# Altium schematic sheet WIFI Module.SchDoc("WIFI Module")
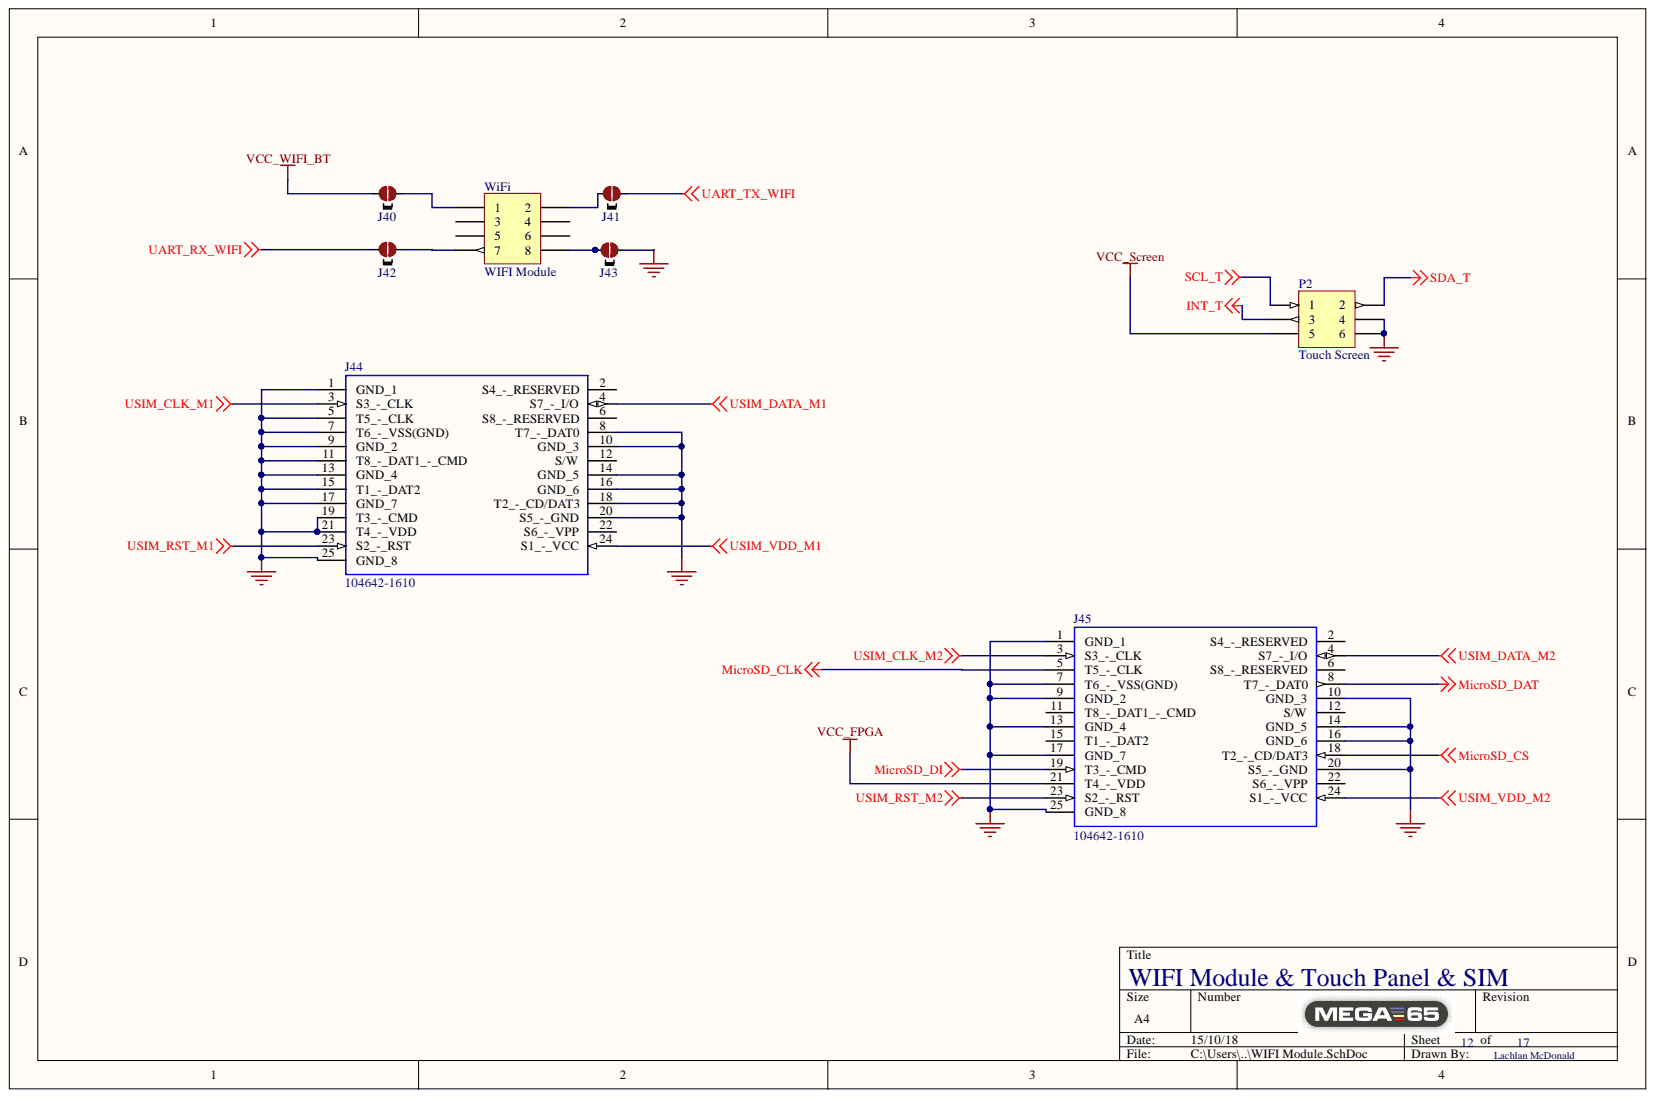
[diagram p0: WIFI Module.SchDoc]

## Parts
J40  2 way net solder junction - Normally Connected  [2waySolder_NC]  (value not drawn)  (no BOM)
J41  2 way net solder junction - Normally Connected  [2waySolder_NC]  (value not drawn)  (no BOM)
J42  2 way net solder junction - Normally Connected  [2waySolder_NC]  (value not drawn)  (no BOM)
J43  2 way net solder junction - Normally Connected  [2waySolder_NC]  (value not drawn)  (no BOM)
J44  104642-1610  [1046421610]
J45  104642-1610  [1046421610]
P2  Touch Screen  [51281-0694]
WiFi  WIFI Module  [HDR2X4]

## Nets
GND: J43.2 J44.1(GND_1) J44.5(T5_-_CLK) J44.7(T6_-_VSS(GND)) J44.8(T7_-_DAT0) J44.9(GND_2) J44.10(GND_3) J44.11(T8_-_DAT1_-_CMD) J44.13(GND_4) J44.14(GND_5) J44.15(T1_-_DAT2) J44.16(GND_6) J44.17(GND_7) J44.18(T2_-_CD/DAT3) J44.19(T3_-_CMD) J44.20(S5_-_GND) J44.21(T4_-_VDD) J44.25(GND_8) J45.1(GND_1) J45.7(T6_-_VSS(GND)) J45.9(GND_2) J45.10(GND_3) J45.13(GND_4) J45.14(GND_5) J45.16(GND_6) J45.17(GND_7) J45.20(S5_-_GND) J45.25(GND_8) P2.4 P2.6
INT_T: P2.3
MicroSD_CLK: J45.5(T5_-_CLK)
MicroSD_CS: J45.18(T2_-_CD/DAT3)
MicroSD_DAT: J45.8(T7_-_DAT0)
MicroSD_DI: J45.19(T3_-_CMD)
SCL_T: P2.1
SDA_T: P2.2
UART_RX_WIFI: J42.1
UART_TX_WIFI: J41.2
USIM_CLK_M1: J44.3(S3_-_CLK)
USIM_CLK_M2: J45.3(S3_-_CLK)
USIM_DATA_M1: J44.4(S7_-_I/O)
USIM_DATA_M2: J45.4(S7_-_I/O)
USIM_RST_M1: J44.23(S2_-_RST)
USIM_RST_M2: J45.23(S2_-_RST)
USIM_VDD_M1: J44.24(S1_-_VCC)
USIM_VDD_M2: J45.24(S1_-_VCC)
VCC_FPGA: J45.21(T4_-_VDD)
VCC_Screen: P2.5
VCC_WIFI_BT: J40.1
NetJ40_2: J40.2 WiFi.1
NetJ41_1: J41.1 WiFi.2
NetJ42_2: J42.2 WiFi.7
NetJ43_1: J43.1 WiFi.8

Not connected: J44 2(S4_-_RESERVED) 6(S8_-_RESERVED) 12(S/W) 22(S6_-_VPP); J45 2(S4_-_RESERVED) 6(S8_-_RESERVED) 11(T8_-_DAT1_-_CMD) 12(S/W) 15(T1_-_DAT2) 22(S6_-_VPP); WiFi 3 4 5 6

## Library data of the parts
J40-J43 = 2waySolderNC: Library Name: NetTieLib.IntLib; Description: 2 way net solder junction - Normally Connected; ComponentLink1URL: http://www.edatools.cz/addons; ComponentLink1Description: Source
J44 J45 = 104642-1610: Molex 104642 Series 8 Way Micro SIM, MicroSD Memory Card Connector with Solder Termination; Geometry.Height: 2mm; Allied_Number: 70890935; RS Part Number: 1213817P; Manufacturer_Part_Number: 104642-1610; Manufacturer_Name: Molex; Library Name: 104642-1610.SchLib; Datasheet Link: http://uk.rs-online.com/web/p/products/1213817P; Component Kind: Standard
P2 = Header 3X2: Header, 3-Pin, Dual row; Signal Integrity: Connector; Library Name: Miscellaneous Connectors.IntLib; LatestRevisionNote: Re-released for DXP Platform.; LatestRevisionDate: 17-Jul-2002; Component Kind: Standard; Publisher: Altium Limited
WiFi = Header 4X2: Header, 4-Pin, Dual row; Signal Integrity: Connector; Library Name: Miscellaneous Connectors.IntLib; LatestRevisionNote: Re-released for DXP Platform.; LatestRevisionDate: 17-Jul-2002; Component Kind: Standard; Publisher: Altium Limited
